# Altium schematic sheet PwrFPGA.SchDoc
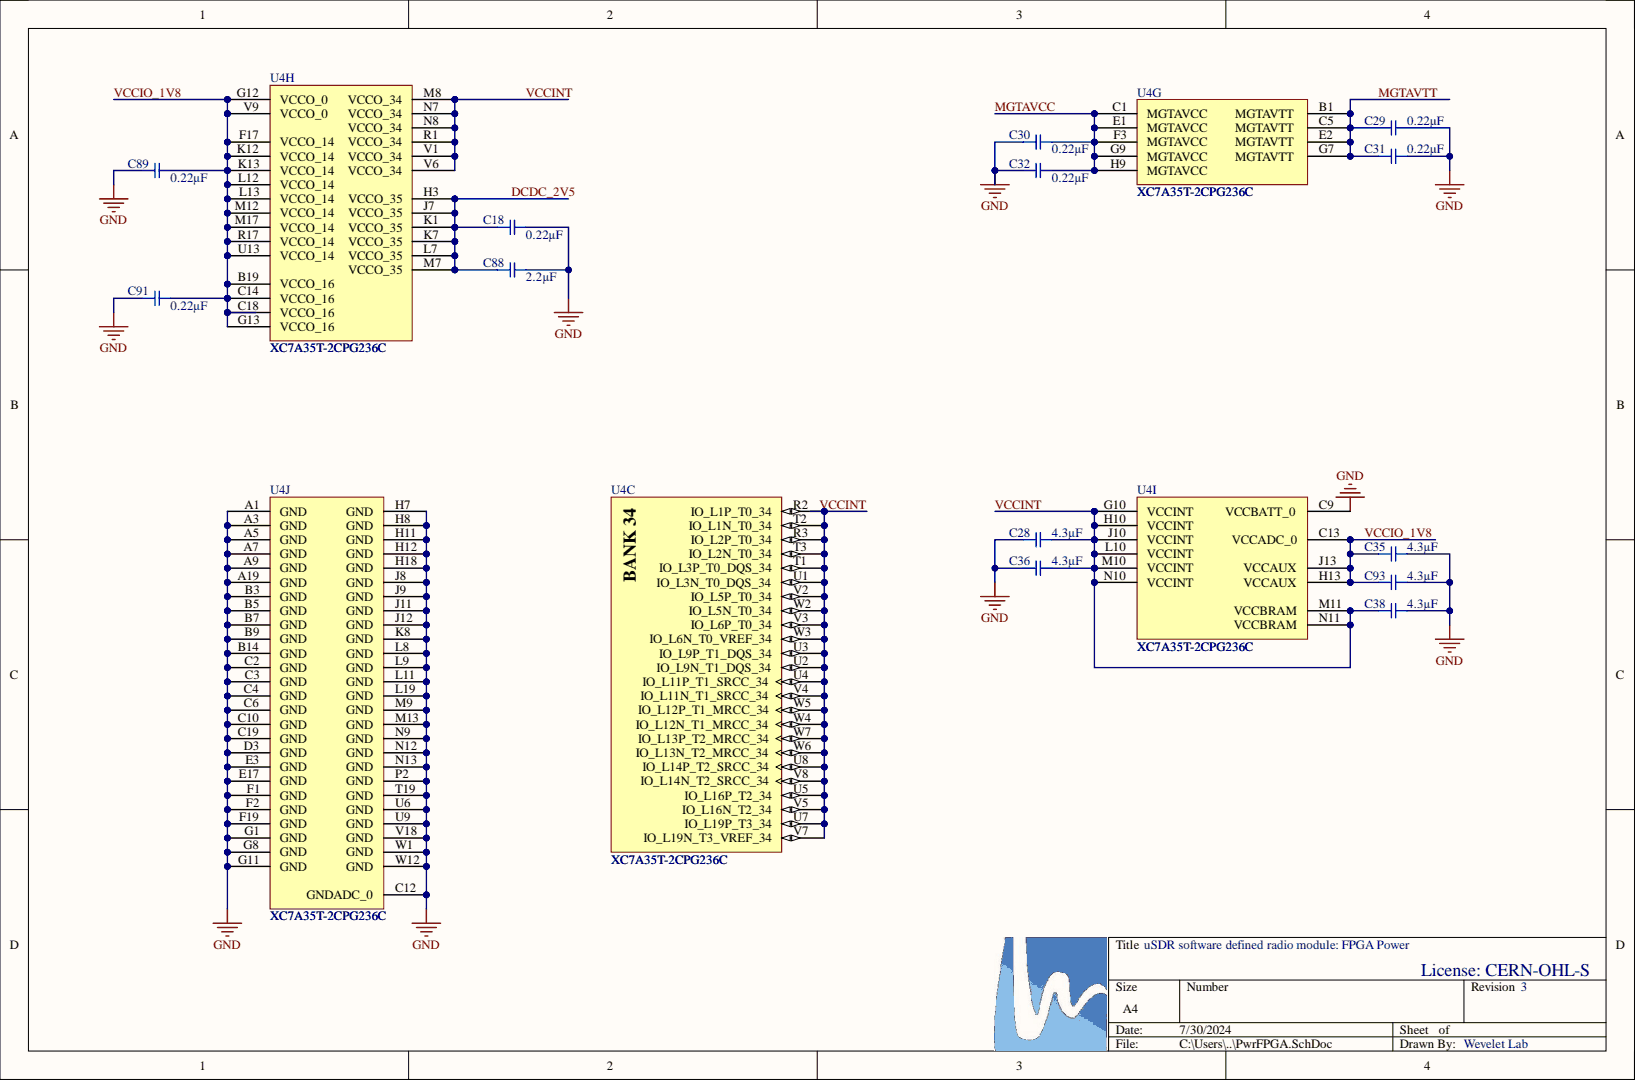
[diagram p0: PwrFPGA.SchDoc]

## Parts
C18  value 0.22µF  [SMD-0201C]  (hidden comment: GRM033C80J224ME90D)
C28  value 4.3µF  [SMD-02404-CAP]  (hidden comment: LLL1U4D80E435ME22)
C29  value 0.22µF  [SMD-0201C]  (hidden comment: GRM033C80J224ME90D)
C30  value 0.22µF  [SMD-0201C]  (hidden comment: GRM033C80J224ME90D)
C31  value 0.22µF  [SMD-0201C]  (hidden comment: GRM033C80J224ME90D)
C32  value 0.22µF  [SMD-0201C]  (hidden comment: GRM033C80J224ME90D)
C35  value 4.3µF  [SMD-02404-CAP]  (hidden comment: LLL1U4D80E435ME22)
C36  value 4.3µF  [SMD-02404-CAP]  (hidden comment: LLL1U4D80E435ME22)
C38  value 4.3µF  [SMD-02404-CAP]  (hidden comment: LLL1U4D80E435ME22)
C88  value 2.2µF  [SMD-0201C]  (hidden comment: GRM033C80G225ME01D)
C89  value 0.22µF  [SMD-0201C]  (hidden comment: GRM033C80J224ME90D)
C91  value 0.22µF  [SMD-0201C]  (hidden comment: GRM033C80J224ME90D)
C93  value 4.3µF  [SMD-02404-CAP]  (hidden comment: LLL1U4D80E435ME22)
U4  XC7A35T-2CPG236C  [CPG236]  (parts C,G,H,I,J)

## Nets
GND: C18.2 C28.1 C29.2 C30.1 C31.2 C32.1 C35.2 C36.1 C38.2 C88.2 C89.1 C91.1 C93.2 U4.A1(GND) U4.A3(GND) U4.A5(GND) U4.A7(GND) U4.A9(GND) U4.A19(GND) U4.B3(GND) U4.B5(GND) U4.B7(GND) U4.B9(GND) U4.B14(GND) U4.C2(GND) U4.C3(GND) U4.C4(GND) U4.C6(GND) U4.C9(VCCBATT_0) U4.C10(GND) U4.C12(GNDADC_0) U4.C19(GND) U4.D3(GND) U4.E3(GND) U4.E17(GND) U4.F1(GND) U4.F2(GND) U4.F19(GND) U4.G1(GND) U4.G8(GND) U4.G11(GND) U4.H7(GND) U4.H8(GND) U4.H11(GND) U4.H12(GND) U4.H18(GND) U4.J8(GND) U4.J9(GND) U4.J11(GND) U4.J12(GND) U4.K8(GND) U4.L8(GND) U4.L9(GND) U4.L11(GND) U4.L19(GND) U4.M9(GND) U4.M13(GND) U4.N9(GND) U4.N12(GND) U4.N13(GND) U4.P2(GND) U4.T19(GND) U4.U6(GND) U4.U9(GND) U4.V18(GND) U4.W1(GND) U4.W12(GND)
DCDC_2V5: C18.1 C88.1 U4.H3(VCCO_35) U4.J7(VCCO_35) U4.K1(VCCO_35) U4.K7(VCCO_35) U4.L7(VCCO_35) U4.M7(VCCO_35)
MGTAVCC: C30.2 C32.2 U4.C1(MGTAVCC) U4.E1(MGTAVCC) U4.F3(MGTAVCC) U4.G9(MGTAVCC) U4.H9(MGTAVCC)
MGTAVTT: C29.1 C31.1 U4.B1(MGTAVTT) U4.C5(MGTAVTT) U4.E2(MGTAVTT) U4.G7(MGTAVTT)
VCCINT: C28.2 C36.2 C38.1 U4.G10(VCCINT) U4.H10(VCCINT) U4.J10(VCCINT) U4.L10(VCCINT) U4.M8(VCCO_34) U4.M10(VCCINT) U4.M11(VCCBRAM) U4.N7(VCCO_34) U4.N8(VCCO_34) U4.N10(VCCINT) U4.N11(VCCBRAM) U4.R1(VCCO_34) U4.R2(IO_L1P_T0_34) U4.R3(IO_L2P_T0_34) U4.T1(IO_L3P_T0_DQS_34) U4.T2(IO_L1N_T0_34) U4.T3(IO_L2N_T0_34) U4.U1(IO_L3N_T0_DQS_34) U4.U2(IO_L9N_T1_DQS_34) U4.U3(IO_L9P_T1_DQS_34) U4.U4(IO_L11P_T1_SRCC_34) U4.U5(IO_L16P_T2_34) U4.U7(IO_L19P_T3_34) U4.U8(IO_L14P_T2_SRCC_34) U4.V1(VCCO_34) U4.V2(IO_L5P_T0_34) U4.V3(IO_L6P_T0_34) U4.V4(IO_L11N_T1_SRCC_34) U4.V5(IO_L16N_T2_34) U4.V6(VCCO_34) U4.V7(IO_L19N_T3_VREF_34) U4.V8(IO_L14N_T2_SRCC_34) U4.W2(IO_L5N_T0_34) U4.W3(IO_L6N_T0_VREF_34) U4.W4(IO_L12N_T1_MRCC_34) U4.W5(IO_L12P_T1_MRCC_34) U4.W6(IO_L13N_T2_MRCC_34) U4.W7(IO_L13P_T2_MRCC_34)
VCCIO_1V8: C35.1 C89.2 C91.2 C93.1 U4.B19(VCCO_16) U4.C13(VCCADC_0) U4.C14(VCCO_16) U4.C18(VCCO_16) U4.F17(VCCO_14) U4.G12(VCCO_0) U4.G13(VCCO_16) U4.H13(VCCAUX) U4.J13(VCCAUX) U4.K12(VCCO_14) U4.K13(VCCO_14) U4.L12(VCCO_14) U4.L13(VCCO_14) U4.M12(VCCO_14) U4.M17(VCCO_14) U4.R17(VCCO_14) U4.U13(VCCO_14) U4.V9(VCCO_0)

## Library data of the parts
C18 C29-C32 C89 C91 = GRM033C80J224ME90D: CAP CER 0.22UF 6.3V X6S 0201; Manufacturer: Murata Electronics North America; @ qty: 0; Minimum Quantity: 1; Tolerance: ±20%; Unit Price (USD): 0.1; Applications: General Purpose; Component Kind: Standard; Datasheets: http://www.murata.com/~/media/webrenewal/support/library/catalog/products/capacitor/mlcc/c02e.pdf; Digi-Key Part Number: 490-9982-1-ND; Factory Stock: 0; Failure Rate: Surface Mount, MLCC; Features: -; Height - Seated (Max): 0.013" (0.33mm); Image: //media.digikey.com/Renders/Murata%20Renders/ZRB-SERIES-0402.jpg; Lead Spacing: -; Mounting Type: 0201 (0603 Metric); Operating Temperature: -55°C ~ 105°C; Package / Case: 0.024" L x 0.012" W (0.60mm x 0.30mm); Packaging: Cut Tape (CT); Part Number: GRM033C80J224ME90D; Part Status: Active; Quantity Available: 381529; Ratings: -; Series: GRM; Size / Dimension: -; Temperature Coefficient: X6S; Thickness (Max): -; Voltage: 6.3V; Library Name: USDR.IntLib
C28 C35 C36 C38 C93 = LLL1U4D80E435ME22: CAP CER 4.3UF 2.5V X6T 02404 (0610M); Manufacturer: Murata Electronics North America; Tolerance: ±20%; Component Kind: Standard; Datasheets: https://search.murata.co.jp/Ceramy/image/img/A01X/G101/ENG/LLL1U4R60G435ME22-01.pdf; Digi-Key Part Number: LLL1U4D80E435ME22-ND; Failure Rate: Surface Mount, MLCC; Mounting Type: 02404 (0610 Metric); Operating Temperature: -55°C ~ 105°C; Package / Case: 0.024" L x 0.039" W (0.60mm x 1.00mm); Part Number: LLL1U4D80E435ME22; Part Status: Active; Series: LLL; Temperature Coefficient: X6T; Voltage: 2.5V; Library Name: USDR.IntLib
C88 = GRM033C80G225ME01D: CAP CER 2.2UF 4V X6S 0201; Manufacturer: Murata Electronics North America; @ qty: 0; Minimum Quantity: 1; Tolerance: ±20%; Unit Price (USD): 0.54; Voltage: 6.3V; Applications: General Purpose; Component Kind: Standard; Datasheets: http://psearch.en.murata.com/capacitor/product/GRM035R60J475ME15%23.pdf; Digi-Key Part Number: 490-GRM033C80G225ME01DTR-ND; Factory Stock: 0; Failure Rate: Surface Mount, MLCC; Features: Derating Recommended; Height - Seated (Max): 0.022" (0.55mm); Image: //media.digikey.com/Renders/Murata%20Renders/ZRB-SERIES-0402.jpg; Lead Spacing: -; Mounting Type: 0201 (0603 Metric); Operating Temperature: -55°C ~105°C; Package / Case: 0.024" L x 0.012" W (0.60mm x 0.30mm); Packaging: Cut Tape (CT); Part Number: GRM033C80G225ME01D; Part Status: Active; Quantity Available: 67188; Ratings: -; Series: GRM; Size / Dimension: -; Temperature Coefficient: X6S; Thickness (Max): -; Library Name: USDR.IntLib
U4 = XC7A35T-2CPG236C: Artix-7 FPGA; Manufacturer: Xilinx; Available User I/O: 106; Clock Mgmt Tiles (CMTs): 5; GTP: 2; Logic Cells: 33280; Total Block RAM: 1800 Kb; Component Kind: Standard; ComponentLink1Description: Manufacturer URL; ComponentLink1URL: http://www.xilinx.com/; ComponentLink2Description: Datasheet; ComponentLink2URL: http://www.xilinx.com/support/documentation/data_sheets/ds180_7Series_Overview.pdf; ComponentLink3Description: Package Specification; ComponentLink3URL: http://www.xilinx.com/support/documentation/user_guides/ug475_7Series_Pkg_Pinout.pdf; ComponentLink4Description: DC and Switching Characteristics; ComponentLink4URL: http://www.xilinx.com/support/documentation/data_sheets/ds181_Artix_7_Data_Sheet.pdf; ComponentLink5Description: Package File; ComponentLink5URL: http://www.xilinx.com/support/packagefiles/a7packages/xc7a200tsbg484pkg.txt; ComponentLink6Description: Packaging and Pinout; ComponentLink6URL: http://www.xilinx.com/support/documentation/user_guides/ug475_7Series_Pkg_Pinout.pdf; DatasheetVersion: v1.15; Maximum Distributed RAM: 400 Kb; Mounting Technology: Surface Mount; NexusDeviceID: XC7A35T-2CPG236C; Operating Temperature Range: Commercial; PackageDescription: 238-Ball Wire-Bond Chip-Scale BGA, Body 10 x10 mm, Pitch 0.5 mm; PackageReference: CPG-236; PackageVersion: Rev. 1.11; PartNumber: XC7A35T-2CPG236C; Speed Grades: 2; Library Name: USDR.IntLib
